# Altium schematic sheet ethernet.SchDoc(ethernet)
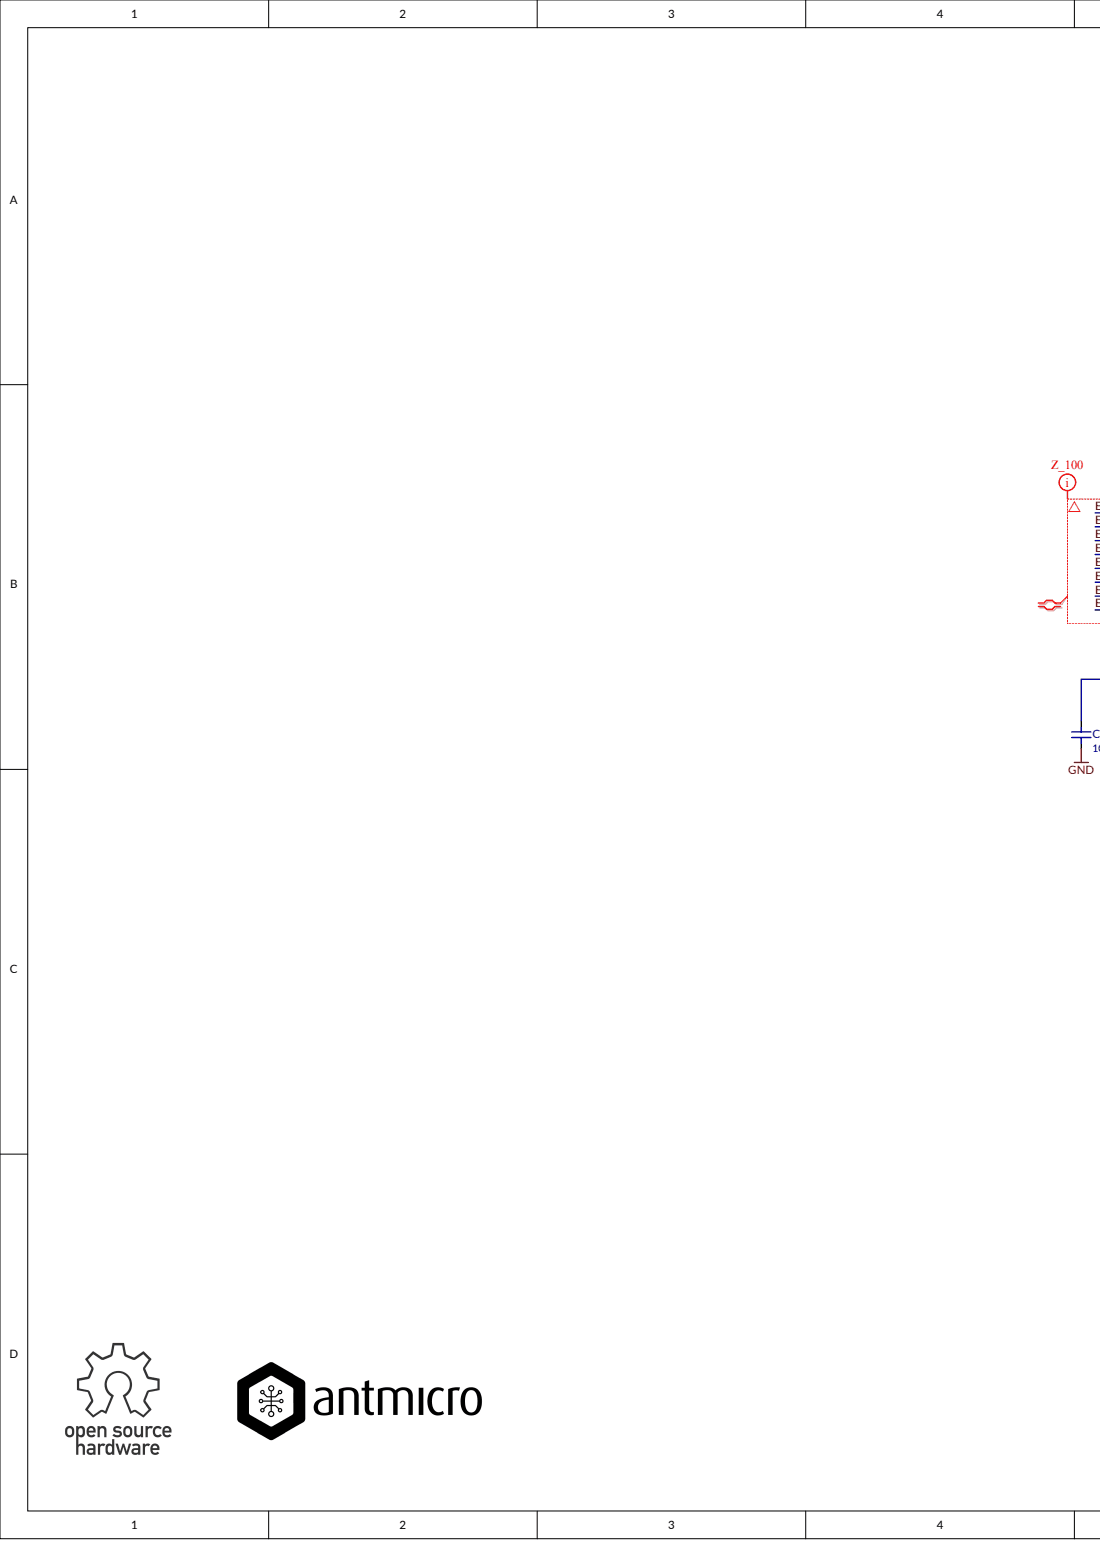
[diagram p0: ethernet.SchDoc, left]
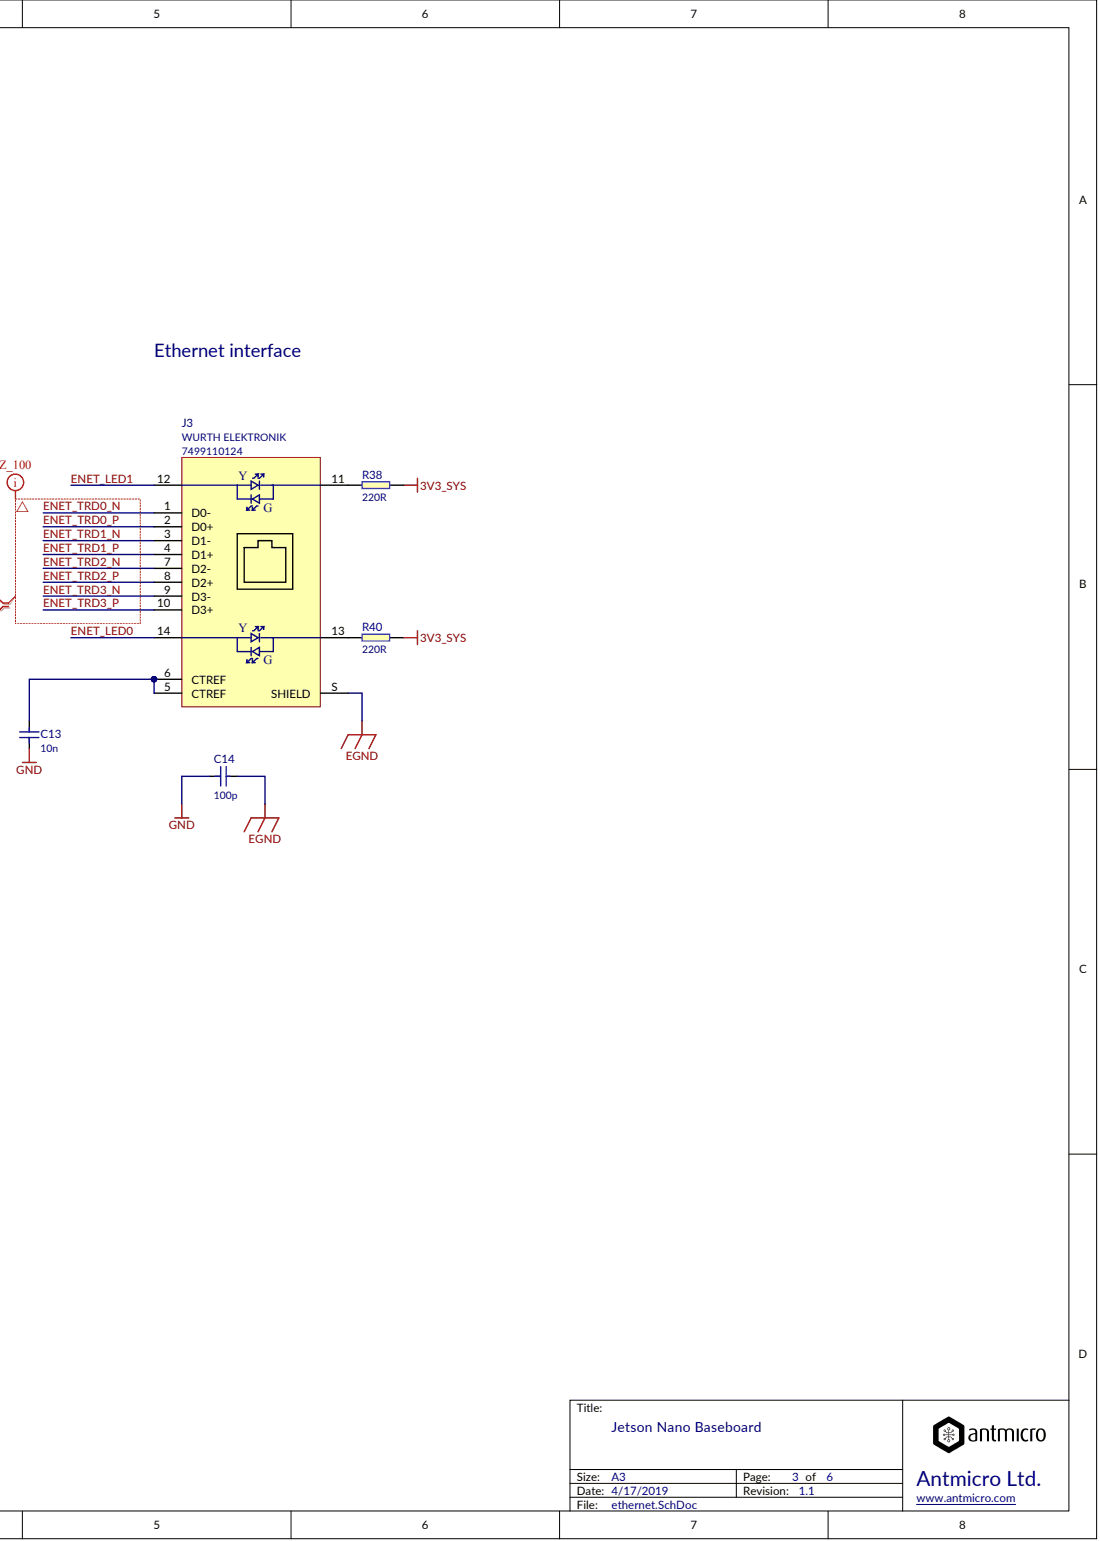
[diagram p1: ethernet.SchDoc, right]

## Parts
C13  10n
C14  100p
J3  Manufacturer: WURTH ELEKTRONIK  PartSymbol: 7499110124  (hidden comment: WE_7499110124)
R38  220R
R40  220R

## Nets
3V3_SYS: R38.2 R40.1
EGND: C14.2 J3.S(SHIELD)
GND: C13.1 C14.1
ENET_LED0: J3.14
ENET_LED1: J3.12
ENET_TRD0_N: J3.1(D0-)
ENET_TRD0_P: J3.2(D0+)
ENET_TRD1_N: J3.3(D1-)
ENET_TRD1_P: J3.4(D1+)
ENET_TRD2_N: J3.7(D2-)
ENET_TRD2_P: J3.8(D2+)
ENET_TRD3_N: J3.9(D3-)
ENET_TRD3_P: J3.10(D3+)
NetC13_2: C13.2 J3.5(CTREF) J3.6(CTREF)
NetJ3_11: J3.11 R38.1
NetJ3_13: J3.13 R40.2
N00230:
N00231:

## Library data of the parts
C13 = (no symbol name): PartNumber: C_10n_0603; Manufacturer: AVX; PartSymbol: 06031C103JAT2A; Value: 10n
C14 = (no symbol name): PartNumber: C_100p_0603; Manufacturer: Walsin; PartSymbol: 0603N101J500CT; Value: 100p
R38 R40 = (no symbol name): PartNumber: R_220R_0402; Manufacturer: TE Connectivity; PartSymbol: CRG0402J220R; Value: 220R
J3 = (no symbol name): PartNumber: WE_7499110124; Value: WE_7499110124
